# Altium schematic sheet device.SchDoc(device)
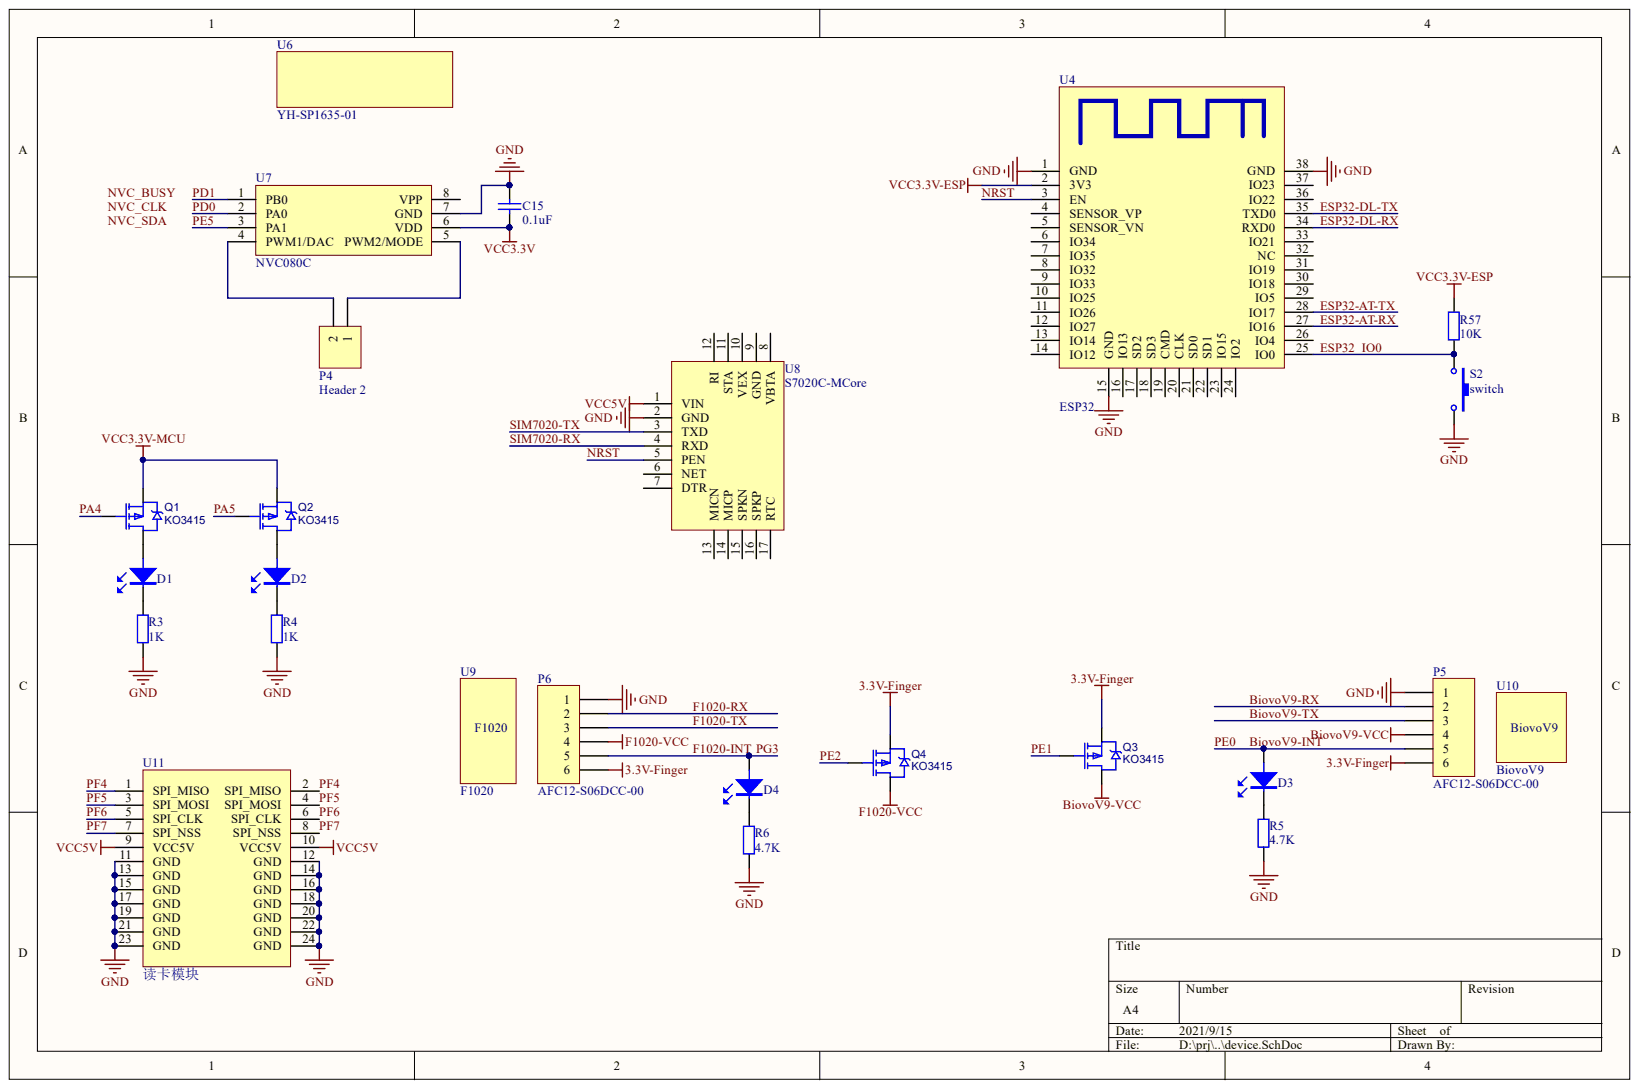
[diagram p0: device.SchDoc]

## Parts
C15  0.1uF  [0603_C]
D1  红色LED  [LED_1206]  (value not drawn)
D2  绿色LED  [LED_1206]  (value not drawn)
D3  LED  [0603_LED_S1]  (value not drawn)
D4  LED  [0603_LED_S1]  (value not drawn)
P4  Header 2  [HDR1X2]
P5  AFC12-S06DCC-00  [CONN-SMD_6PIN_AFC12-S06DCC-00_PITCH1.25-L]
P6  AFC12-S06DCC-00  [CONN-SMD_6PIN_AFC12-S06DCC-00_PITCH1.25-L]
Q1  KO3415  [SOT-23(SOT-23-3)]
Q2  KO3415  [SOT-23(SOT-23-3)]
Q3  KO3415  [SOT-23(SOT-23-3)]
Q4  KO3415  [SOT-23(SOT-23-3)]
R3  1K  [0603_R]
R4  1K  [0603_R]
R5  4.7K  [0603_R]
R6  4.7K  [0603_R]
R57  10K  [0603_R]
S2  switch  [SW-SMD_4P-L6.2-W6.2-P4.50-LS9.0]
U4  ESP32  [ESP32]
U6  YH-SP1635-01  [YH-SP1635-01]
U7  NVC080C  [SOP-8_150mil]
U8  S7020C-MCore  [S7020C-MCore]
U9  F1020  [F1020]
U10  BiovoV9  [BiovoV9]
U11  读卡模块  [readCardBoard]

## Nets
3.3V-FINGER: P5.6 P6.6 Q3.2 Q4.2
BIOVOV9-VCC: P5.4 Q3.3
F1020-VCC: P6.4 Q4.3
GND: C15.2 P5.1 P6.1 R3.1 R4.1 R5.1 R6.1 S2.2 U4.1(GND) U4.15(GND) U4.38(GND) U7.7(GND) U8.2(GND) U11.11(GND) U11.12(GND) U11.13(GND) U11.14(GND) U11.15(GND) U11.16(GND) U11.17(GND) U11.18(GND) U11.19(GND) U11.20(GND) U11.21(GND) U11.22(GND) U11.23(GND) U11.24(GND)
VCC3.3V: C15.1 U7.6(VDD)
VCC3.3V-ESP: R57.2 U4.2(3V3)
VCC3.3V-MCU: Q1.2 Q2.2
VCC5V: U8.1(VIN) U11.9(VCC5V) U11.10(VCC5V)
BIOVOV9-INT: D3.A P5.5
BIOVOV9-RX: P5.2
BIOVOV9-TX: P5.3
ESP32-AT-RX: U4.27(IO16)
ESP32-AT-TX: U4.28(IO17)
ESP32-DL-RX: U4.34(RXD0)
ESP32-DL-TX: U4.35(TXD0)
ESP32_IO0: R57.1 S2.1 U4.25(IO0)
F1020-INT: D4.A P6.5
F1020-RX: P6.2
F1020-TX: P6.3
NRST: U4.3(EN) U8.5(PEN)
PA4: Q1.1
PA5: Q2.1
PD0: U7.2(PA0)
PD1: U7.1(PB0)
PE1: Q3.1
PE2: Q4.1
PE5: U7.3(PA1)
PF4: U11.1(SPI_MISO) U11.2(SPI_MISO)
PF5: U11.3(SPI_MOSI) U11.4(SPI_MOSI)
PF6: U11.5(SPI_CLK) U11.6(SPI_CLK)
PF7: U11.7(SPI_NSS) U11.8(SPI_NSS)
SIM7020-RX: U8.4(RXD)
SIM7020-TX: U8.3(TXD)
NetD1_A: D1.A Q1.3
NetD1_K: D1.K R3.2
NetD2_A: D2.A Q2.3
NetD2_K: D2.K R4.2
NetD3_K: D3.K R5.2
NetD4_K: D4.K R6.2
NetP4_1: P4.1 U7.5(PWM2/MODE)
NetP4_2: P4.2 U7.4(PWM1/DAC)
NVC_BUSY:
NVC_CLK:
NVC_SDA:

Not connected: U4 4(SENSOR_VP) 5(SENSOR_VN) 6(IO34) 7(IO35) 8(IO32) 9(IO33) 10(IO25) 11(IO26) 12(IO27) 13(IO14) 14(IO12) 16(IO13) 17(SD2) 18(SD3) 19(CMD) 20(CLK) 21(SD0) 22(SD1) 23(IO15) 24(IO2) 26(IO4) 29(IO5) 30(IO18) 31(IO19) 32(NC) 33(IO21) 36(IO22) 37(IO23); U7 8(VPP); U8 6(NET) 7(DTR) 8(VBTA) 9(GND) 10(VEX) 11(STA) 12(RI) 13(MICN) 14(MICP) 15(SPKN) 16(SPKP) 17(RTC)

## Library data of the parts
C15 = Cap: 贴片电容，0603; Component Kind: Standard; Library Name: stm8l052r8t6开发板.SchLib
D1 = LED: 1206封装，红色LED; Component Kind: Standard; Library Name: stm8l052r8t6开发板.SchLib
D2 = LED: 1206封装，绿色LED; Component Kind: Standard; Library Name: stm8l052r8t6开发板.SchLib
D3 D4 = LED: 贴片LED，0603，白色; Component Kind: Standard; Library Name: stm8l052r8t6开发板.SchLib
P4 = Header 2: 不焊; Component Kind: Standard; LatestRevisionDate: 17-Jul-2002; LatestRevisionNote: Re-released for DXP Platform.; Library Name: Miscellaneous Connectors.IntLib; Signal Integrity: Connector; Publisher: Altium Limited
P5 P6 = Header 6: 1.25MM 6P 卧式贴片插座连接器; Component Kind: Standard; Library Name: Miscellaneous Connectors.IntLib
Q1-Q4 = 3415: KO3415,SOT23-3; Component Kind: Standard; Library Name: stm8l052r8t6开发板.SchLib
R3-R6 R57 = Res2: 贴片电阻，0603; Component Kind: Standard; Library Name: stm8l052r8t6开发板.SchLib
S2 = switch: 贴片按键，6*6*5; Component Kind: Standard; Library Name: stm8l052r8t6开发板.SchLib
U6 = speaker: 1635腔体喇叭; Component Kind: Standard; Library Name: stm8l052r8t6开发板.SchLib
U7 = NVCXXXC: 语音芯片，SOP-8; Component Kind: Standard; Library Name: stm8l052r8t6开发板.SchLib
U8 = S7020C: Component Kind: Standard; Description: S7020C-MCore; Library Name: stm8l052r8t6开发板.SchLib
U9 = F1020: 不焊; Component Kind: Standard; Library Name: stm8l052r8t6开发板.SchLib
U10 = BiovoV9: 不焊; Component Kind: Standard; Library Name: stm8l052r8t6开发板.SchLib
U11 = readCardBoard: 不焊; Component Kind: Standard; Library Name: stm8l052r8t6开发板.SchLib
U4 = ESP32: Component Kind: Standard; Description: ESP32; Library Name: 智能锁实践教学平台.SchLib
